# Altium schematic sheet PFM_photodiode.SchDoc(PFM_photodiode)
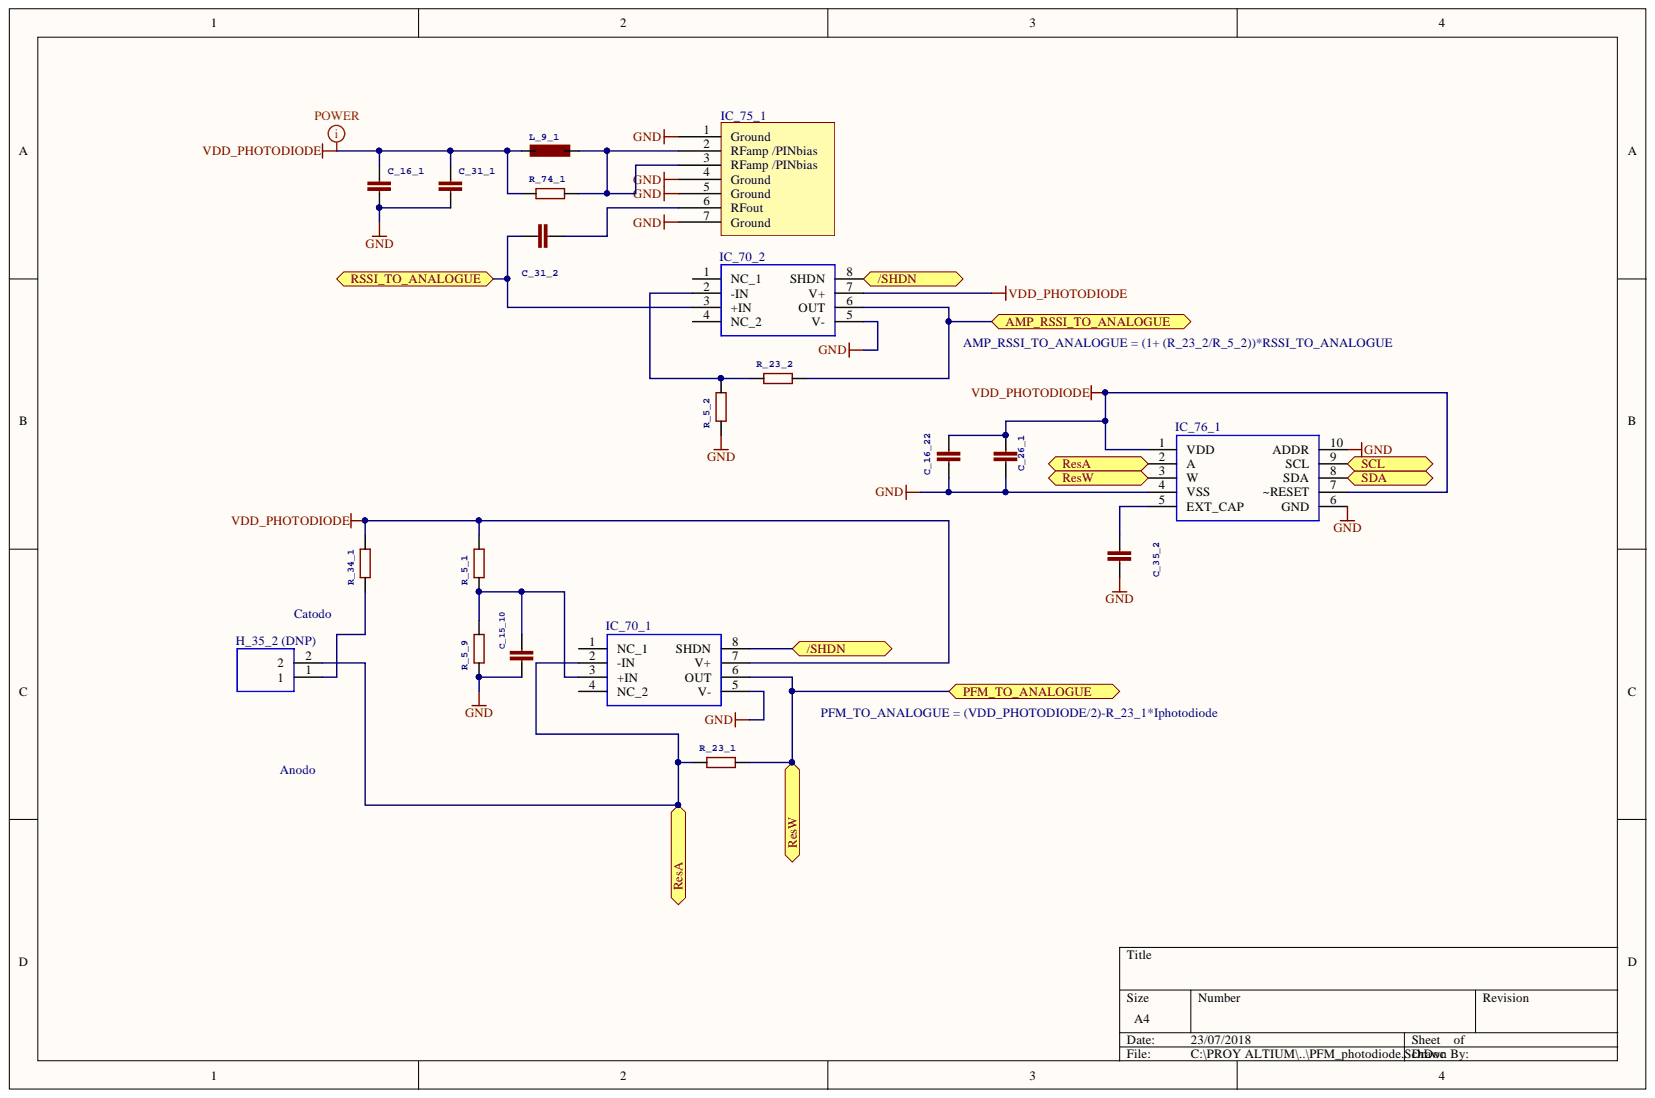
[diagram p0: PFM_photodiode.SchDoc]

## Parts
C_15_10  100nF;GRM155R71A104KA01D;Murata;  [C0402]  (value not drawn)
C_16_1  100nF;CL10B104KB8NNNC;Samsung;  [C0603K]  (value not drawn)
C_16_22  100nF;CL10B104KB8NNNC;Samsung  [C0603K]  (value not drawn)
C_26_1  10uF;0603X106M6R3CT;Walsin  [C0603K]  (value not drawn)
C_31_1  1nF;GRM188R71H102KA01D;Murata;  [C0603K]  (value not drawn)
C_31_2  1nF;GRM188R71H102KA01D;Murata;  [C0603K]  (value not drawn)
C_35_2  1uF;MC0603F105Z100CT;MULTICOMP  [C0603K]  (value not drawn)
H_35_2_(DNP)  B2B-EH-A(LF)(SN);JST  [SHDR2W64P0X250_1X2_750X380X600P]  (value not drawn)
IC_70_1  LTC6268HS8#PBF;LinearTechnology  [SOIC127P599X175-8N]  (value not drawn)
IC_70_2  LTC6268HS8#PBF;LinearTechnology  [SOIC127P599X175-8N]  (value not drawn)
IC_75_1  AFBR2310Z;Avago;  [FPC_7pos_0p8mm]  (value not drawn)
IC_76_1  AD5272BRMZ-100;AnalogDevices  [SOP50P490X110-10N]  (value not drawn)
L_9_1  27nH;L-14C27NJV4T;Johanson;  [L2012C]  (value not drawn)
R_5_1  1k;ERJ-3GEYJ102V;Panasonic  [R0603]  (value not drawn)
R_5_2  1k;ERJ-3GEYJ102V;Panasonic  [R0603]  (value not drawn)
R_5_9  1k;ERJ-3GEYJ102V;Panasonic  [R0603]  (value not drawn)
R_23_1  120k;ERJ3GEYJ124V;Panasonic  [R0603]  (value not drawn)
R_23_2  120k;ERJ3GEYJ124V;Panasonic  [R0603]  (value not drawn)
R_34_1  47k;RC0603JR-0747KL;Yageo  [R0603]  (value not drawn)
R_74_1  8.2;RC0201FR-078R2L;Yageo;  [R0603]  (value not drawn)

## Ports
/SHDN, AMP_RSSI_TO_ANALOGUE, PFM_TO_ANALOGUE, ResA, ResW, RSSI_TO_ANALOGUE, SCL, SDA

## Nets
GND: C_15_10.2 C_16_1.2 C_16_22.1 C_26_1.1 C_31_1.2 C_35_2.1 IC_70_1.5(V-) IC_70_2.5(V-) IC_75_1.1(Ground) IC_75_1.4(Ground) IC_75_1.5(Ground) IC_75_1.7(Ground) IC_76_1.4(VSS) IC_76_1.6(GND) IC_76_1.10(ADDR) R_5_2.1 R_5_9.1
VDD_PHOTODIODE: C_16_1.1 C_16_22.2 C_26_1.2 C_31_1.1 IC_70_1.7(V+) IC_70_2.7(V+) IC_76_1.1(VDD) IC_76_1.7(~RESET) L_9_1.2 R_5_1.2 R_34_1.2 R_74_1.1
/SHDN: IC_70_1.8(SHDN) IC_70_2.8(SHDN) ; port /SHDN
AMP_RSSI_TO_ANALOGUE: IC_70_2.6(OUT) R_23_2.2 ; port AMP_RSSI_TO_ANALOGUE
PFM_TO_ANALOGUE: IC_70_1.6(OUT) IC_76_1.3(W) R_23_1.2 ; port PFM_TO_ANALOGUE, port ResW
ResA: H_35_2_(DNP).2 IC_70_1.2(-IN) IC_76_1.2(A) R_23_1.1 ; port ResA
RSSI_TO_ANALOGUE: C_31_2.1 IC_70_2.3(+IN) ; port RSSI_TO_ANALOGUE
SCL: IC_76_1.9(SCL) ; port SCL
SDA: IC_76_1.8(SDA) ; port SDA
NetC_15_10_1: C_15_10.1 IC_70_1.3(+IN) R_5_1.1 R_5_9.2
NetC_31_2_2: C_31_2.2 IC_75_1.6(RFout)
NetC_35_2_2: C_35_2.2 IC_76_1.5(EXT_CAP)
NetH_35_2 (DNP)_1: H_35_2_(DNP).1 R_34_1.1
NetIC_70_2_2: IC_70_2.2(-IN) R_5_2.2 R_23_2.1
NetIC_75_1_2: IC_75_1.2(RFamp_/PINbias) IC_75_1.3(RFamp_/PINbias) L_9_1.1 R_74_1.2

Not connected: IC_70_1 1(NC_1) 4(NC_2); IC_70_2 1(NC_1) 4(NC_2)

## Library data of the parts
C_15_10 = C-EUC0402: CAPACITOR, European symbol; Library Name: rcl.IntLib; Component Kind: Standard
C_16_1 C_16_22 C_26_1 C_31_1 C_31_2 C_35_2 = C-EUC0603K: Library Name: PBv4p0.SCHLIB; Component Kind: Standard
H_35_2_(DNP) = B2B-EH-A(LF)(SN): JST (JAPAN SOLDERLESS TERMINALS) - B2B-EH-A(LF)(SN) - CONNECTOR, HEADER, 2POS, 2.5MM, 1ROW; Geometry.Height: 6mm; Manufacturer_Part_Number: B2B-EH-A(LF)(SN); Manufacturer_Name: JST (JAPAN SOLDERLESS TERMINALS); Library Name: B2B-EH-A(LF)(SN).SchLib; Datasheet Link: http://www.farnell.com/datasheets/2057210.pdf; Component Kind: Standard; Arrow Price/Stock: https://www.arrow.com/en/products/b2b-eh-a-lf-sn/jst-manufacturing; Arrow Part Number: B2B-EH-A(LF)(SN)
IC_70_1 IC_70_2 = LTC6268HS8#PBF: Ultra-Low Bias Current FET Input Op Amp; Geometry.Height: 1.752mm; Manufacturer_Part_Number: LTC6268HS8#PBF; Manufacturer_Name: Linear Technology; Library Name: LTC6268HS8#PBF.SchLib; Datasheet Link: http://cds.linear.com/docs/en/datasheet/62689f.pdf; Component Kind: Standard; Arrow Price/Stock: https://www.arrow.com/en/products/ltc6268hs8pbf/linear-technology; Arrow Part Number: LTC6268HS8#PBF
IC_75_1 = AFBR2310Z: Component Kind: Standard; Library Name: PFM_SA_v1p0.SCHLIB
IC_76_1 = AD5272BRMZ-100: 1024-/256-Position, 1% Resistor Tolerance Error, I2C Interface and 50-TP Memory Digital Rheostat; RS Price/Stock: http://uk.rs-online.com/web/p/products/1601643; RS Part Number: 1601643; Manufacturer_Part_Number: AD5272BRMZ-100; Manufacturer_Name: Analog Devices; Library Name: AD5272BRMZ-100.SchLib; Datasheet Link: http://componentsearchengine.com/Datasheets/3/AD5272BRMZ-100.pdf; Component Kind: Standard; Arrow Price/Stock: https://www.arrow.com/en/products/ad5272brmz-100/analog-devices; Arrow Part Number: AD5272BRMZ-100
L_9_1 = L-EUL2012C: Component Kind: Standard; Library Name: Easynet_Commboard_v1p1.SCHLIB
R_5_1 R_5_2 R_5_9 R_23_1 R_23_2 R_34_1 R_74_1 = R-EU_R0603: RESISTOR, European symbol; Library Name: PBv4p0.SCHLIB; Component Kind: Standard
